# Altium schematic sheet lv_mcu_ios_100_power.SchDoc(lv_mcu_ios_100_power)
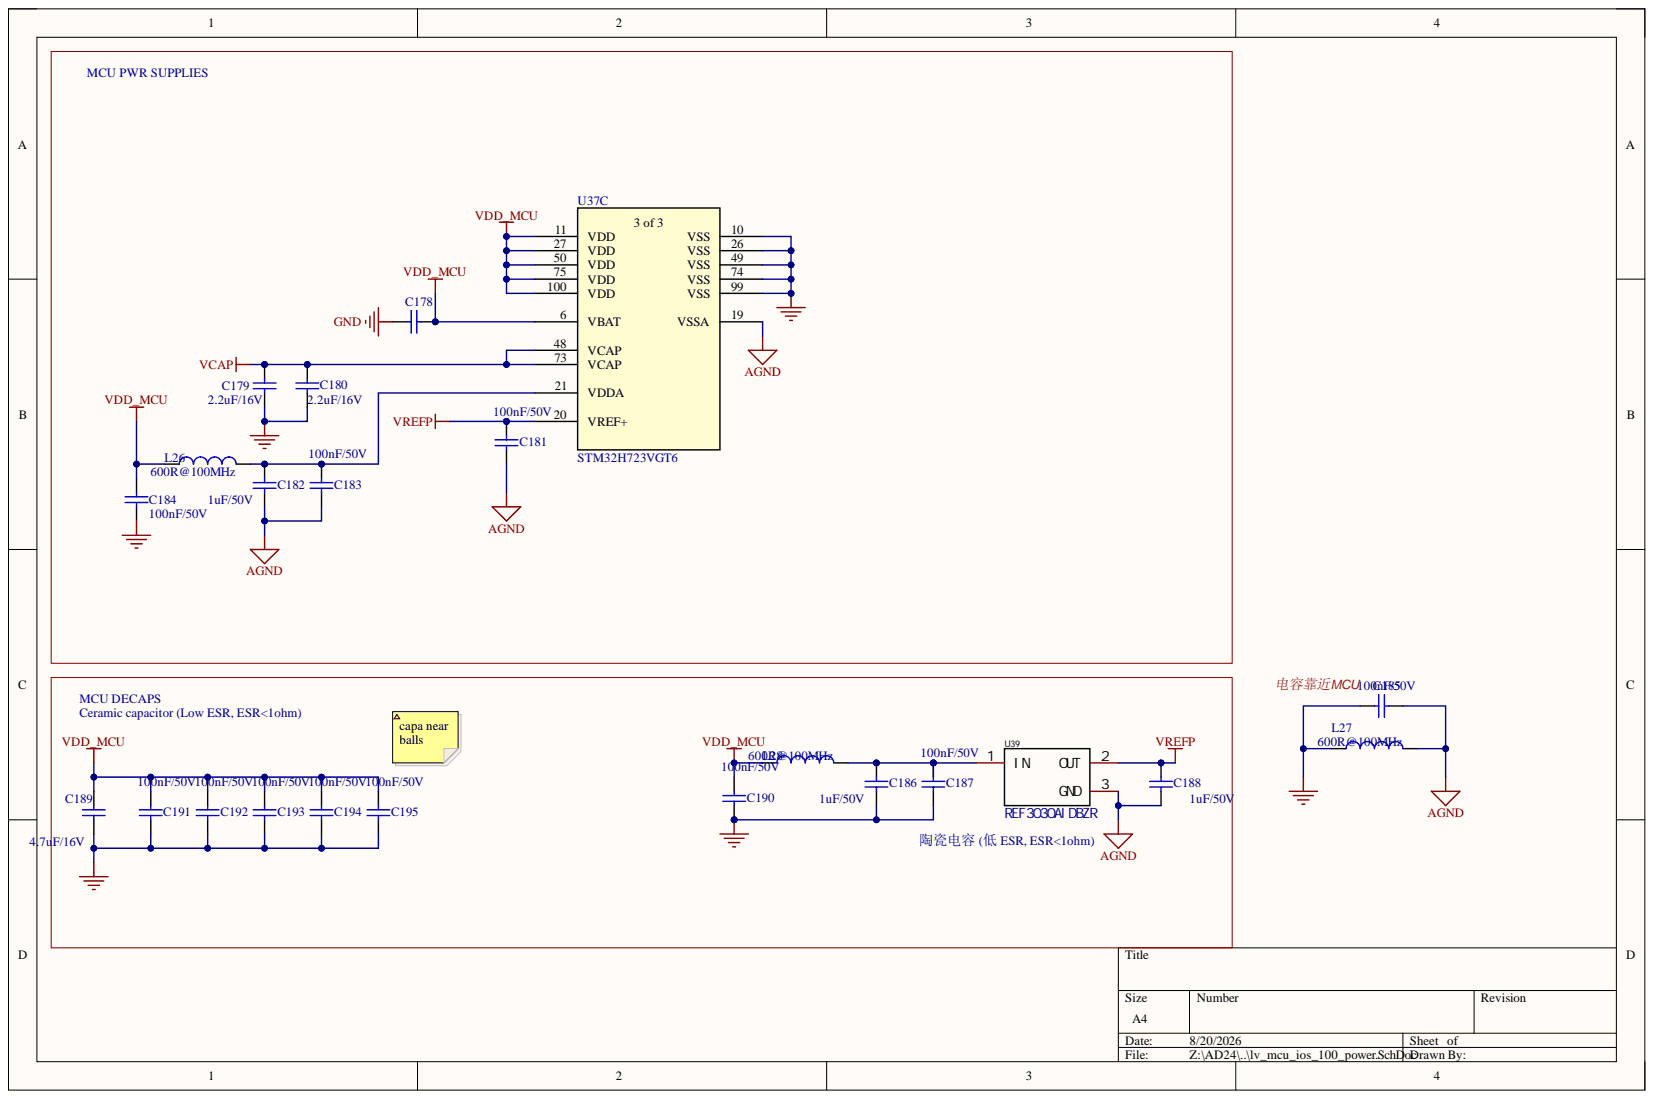
[diagram p0: lv_mcu_ios_100_power.SchDoc]

## Parts
C178  1uF/50V  [C0603]  (value not drawn)
C179  2.2uF/16V  [C0603]
C180  2.2uF/16V  [C0603]
C181  100nF/50V  [C0402]
C182  1uF/50V  [C0603]
C183  100nF/50V  [C0402]
C184  100nF/50V  [C0402]
C185  100nF/50V  [C0402]
C186  1uF/50V  [C0603]
C187  100nF/50V  [C0402]
C188  1uF/50V  [C0603]
C189  4.7uF/16V  [C0603]
C190  100nF/50V  [C0402]
C191  100nF/50V  [C0402]
C192  100nF/50V  [C0402]
C193  100nF/50V  [C0402]
C194  100nF/50V  [C0402]
C195  100nF/50V  [C0402]
L26  600R@100MHz  [L0603]
L27  600R@100MHz  [L0603]
L28  600R@100MHz  [L0603]
U37  STM32H723VGT6  [LQFP100_STM-L]  (part C)
U39  REF3030AIDBZR  [SOT-23-3_L2.9-W1.6-P1.90-LS2.8-BR]

## Nets
AGND: C181.1 C182.2 C183.1 C185.1 C188.1 L27.2 U37.19(VSSA) U39.3
GND: C178.2 C179.1 C180.1 C184.2 C185.2 C186.1 C187.1 C189.1 C190.1 C191.1 C192.1 C193.1 C194.1 C195.1 L27.1 U37.10(VSS) U37.26(VSS) U37.49(VSS) U37.74(VSS) U37.99(VSS)
VCAP: C179.2 C180.2 U37.48(VCAP) U37.73(VCAP)
VDD_MCU: C178.1 C184.1 C189.2 C190.2 C191.2 C192.2 C193.2 C194.2 C195.2 L26.1 L28.1 U37.6(VBAT) U37.11(VDD) U37.27(VDD) U37.50(VDD) U37.75(VDD) U37.100(VDD)
VREFP: C181.2 C188.2 U37.20(VREF+) U39.2
NetC182_1: C182.1 C183.2 L26.2 U37.21(VDDA)
NetC186_2: C186.2 C187.2 L28.2 U39.1

## Library data of the parts
C178 = MCD-00238: 50V,±10%,X5R; Manufacturer: YAGEO; Manufacturer_Part_Number: CC0603KRX5R7BB105; Database Table Name: MCD_PARTS$; Component Kind: Standard; ComponentLink1Description: Datasheet; ComponentLink1URL: http://tunmcdsvn.tun.st.com/Component_Datasheet_Library/Trunk/Capacitors/Yageo_Multilayer_capacitor_X5R_series_100pF_to_100uF; Library Name: MCD_PARTS_database.DbLib
C179 = MCD-00012: 10%,X5R; Manufacturer: YAGEO; Manufacturer_Part_Number: CC0603KRX5R6BB225; Database Table Name: MCD_PARTS$; Component Kind: Standard; ComponentLink1Description: Datasheet; ComponentLink1URL: http://tunmcdsvn.tun.st.com/Component_Datasheet_Library/Trunk/Capacitors/Yageo_Multilayer_capacitor_X5R_series_100pF_to_100uF; Library Name: MCD_PARTS_database.DbLib
C180 = MCD-00012: X5R, 10%; Alternate_Manufacturer_Part_Number: CC0603KRX5R6BB225; Manufacturer: YAGEO; Database Table Name: MCD_PARTS$; Component Kind: Standard; ComponentLink1Description: Datasheet; ComponentLink1URL: http://tunmcdsvn.tun.st.com/Component_Datasheet_Library/Trunk/Capacitors/Yageo_Multilayer_capacitor_X5R_series_100pF_to_100uF; Library Name: MCD_PARTS_database.DbLib
C181 C183 C191-C194 = MCD-01123: X7R, 10%; Manufacturer: MURATA; Manufacturer_Part_Number: GRM155R71H104KE14D; Database Table Name: MCD_PARTS$; ECCN_EU: NEC; ECCN_US: EAR99; Component Kind: Standard; ComponentLink1Description: Datasheet; ComponentLink1URL: http://tunmcdsvn.tun.st.com/Component_Datasheet_Library/Trunk/Capacitors/Murata_Capacitor_GR_series; Library Name: MCD_PARTS_database.DbLib
C182 = MCD-00238: X5R, 10%; Manufacturer: YAGEO; Manufacturer_Part_Number: CC0603KRX5R7BB105; Database Table Name: MCD_PARTS$; Component Kind: Standard; ComponentLink1Description: Datasheet; ComponentLink1URL: http://tunmcdsvn.tun.st.com/Component_Datasheet_Library/Trunk/Capacitors/Yageo_Multilayer_capacitor_X5R_series_100pF_to_100uF; Library Name: MCD_PARTS_database.DbLib
C184 = MCD-00006: X7R, 10%; Alternate_Manufacturer: MURATA; Alternate_Manufacturer_Part_Number: GCM155R71C104KA55D; Manufacturer_Part_Number: GRM155R71H104KE14D; Database Table Name: MCD_PARTS$; ECCN_EU: NEC; ECCN_US: EAR99; Component Kind: Standard; ComponentLink1Description: Datasheet; ComponentLink1URL: http://tunmcdsvn.tun.st.com/Component_Datasheet_Library/Trunk/Capacitors/Yageo_Multilayer_Capacitor_X7R_series_100pF_to_22uF; Library Name: MCD_PARTS_database.DbLib; Manufacturer: MURATA
C185 = MCD-00006: X7R, 10%; Alternate_Manufacturer: MURATA; Alternate_Manufacturer_Part_Number: GCM155R71C104KA55D; Manufacturer_Part_Number: GRM155R71H104KE14D; Database Table Name: MCD_PARTS$; Initial_Board_Reference: MB1274; Item Type: Item; Path: ws/MCD_ApplicationBoards/Lists/MCDPARTSdatabase; Component Kind: Standard; ComponentLink1Description: Datasheet; ComponentLink1URL: http://tunmcdsvn.tun.st.com/Component_Datasheet_Library/Trunk/Capacitors/Yageo_Multilayer_Capacitor_X7R_series_100pF_to_22uF; Library Name: MCD_PARTS_database.DbLib; Manufacturer: MURATA
C186 C188 = MCD-00010: X5R, 10%; Manufacturer: YAGEO; Manufacturer_Part_Number: CC0603KRX5R7BB105; Component Kind: Standard; Library Name: MB1397.IntLib
  C188: ComponentLink1Description: Datasheet; ComponentLink1URL: http://tunmcdsvn.tun.st.com/Component_Datasheet_Library/Trunk/Capacitors/Yageo_Multilayer_capacitor_X5R_series_100pF_to_100uF
C187 = MCD-00006: X7R, 10%; Alternate_Manufacturer: MURATA; Alternate_Manufacturer_Part_Number: GCM155R71C104KA55D; Manufacturer_Part_Number: GRM155R71H104KE14D; Initial_Board_Reference: MB1274; Item Type: Item; Path: ws/MCD_ApplicationBoards/Lists/MCDPARTSdatabase; Component Kind: Standard; ComponentLink1Description: Datasheet; ComponentLink1URL: http://tunmcdsvn.tun.st.com/Component_Datasheet_Library/Trunk/Capacitors/Yageo_Multilayer_Capacitor_X7R_series_100pF_to_22uF; Library Name: MB1397.IntLib; Manufacturer: MURATA
C189 = MCD-01121: 16V, X7S, 10%,; Manufacturer: MURATA; Manufacturer_Part_Number: GRM188R61C475KE11D; Database Table Name: MCD_PARTS$; Component Kind: Standard; ComponentLink1Description: Datasheet; ComponentLink1URL: http://tunmcdsvn.tun.st.com/Component_Datasheet_Library/Trunk/Capacitors/Murata_Capacitor_GR_series; Library Name: MCD_PARTS_database.DbLib
C190 = MCD-00102: X7R, 10%; Manufacturer: MURATA; Manufacturer_Part_Number: GRM155R71H104KE14D; Database Table Name: MCD_PARTS$; Component Kind: Standard; ComponentLink1Description: Yageo_Capacitor_X7R-series.pdf; ComponentLink1URL: http://tunmcdsvn.tun.st.com/Component_Datasheet_Library/Trunk/Capacitors/Yageo_Multilayer_Capacitor_X7R_series_100pF_to_22uF; Library Name: MCD_PARTS_database.DbLib
C195 = MCD-01123: X7R, 10%; Manufacturer: MURATA; Manufacturer_Part_Number: GRM155R71H104KE14D; Database Table Name: MCD_PARTS$; Component Kind: Standard; ComponentLink1Description: Datasheet; ComponentLink1URL: http://tunmcdsvn.tun.st.com/Component_Datasheet_Library/Trunk/Capacitors/Murata_Capacitor_GR_series; Library Name: MCD_PARTS_database.DbLib
L26 L28 = MCD-00047: +/-25%, 350mA, 500mohm DC; Manufacturer_Part_Number: FCM1608KF-601T03; Database Table Name: MCD_PARTS$; Component Kind: Standard; ComponentLink1Description: Datasheet; ComponentLink1URL: http://tunmcdsvn.tun.st.com/Component_Datasheet_Library/Trunk/Inductors/TaiTech_Bead_FCM1608_series; ECCN_EU: n/a; ECCN_US: n/a; Library Name: MCD_PARTS_database.DbLib
L27 = MCD-00047: +/-25%, 350mA, 500mohm DC; Manufacturer_Part_Number: FCM1608KF-601T03; Database Table Name: MCD_PARTS$; Initial_Board_Reference: MB1274; Item Type: Item; Path: ws/MCD_ApplicationBoards/Lists/MCDPARTSdatabase; Component Kind: Standard; ComponentLink1Description: Datasheet; ComponentLink1URL: http://tunmcdsvn.tun.st.com/Component_Datasheet_Library/Trunk/Inductors/TaiTech_Bead_FCM1608_series; Library Name: MCD_PARTS_database.DbLib
U37 = STM32H723VGT6: No Description Available; Mfr_Name: STMicroelectronics; Component Kind: Standard; Library Name: HiPin_Library_AD.IntLib; RefDes: RefDes; Type: Type
U39 = REF3030AIDBZR: Manufacturer: TI(德州仪器); Add into BOM: yes; Component Kind: Standard; Convert to PCB: yes; Datasheet: https://atta.szlcsc.com/upload/public/pdf/source/20141125/1457707248562.pdf; Device: REF3030AIDBZR; JLCPCB Part Class: Extended Part; LCSC Part Name: REF3030AIDBZR; Library Name: HiPin_Library_AD.IntLib; Manufacturer Part: REF3030AIDBZR; Name: REF3030AIDBZR; PCB3D: SOT-23-3L_L2.9-W1.6-H1.1-LS2.8-P0.95; Supplier: LCSC; Supplier Footprint: SOT-23; Supplier Part: C38423; Symbol: REF3030AIDBZR
